# Altium schematic sheet Sheet2.SchDoc
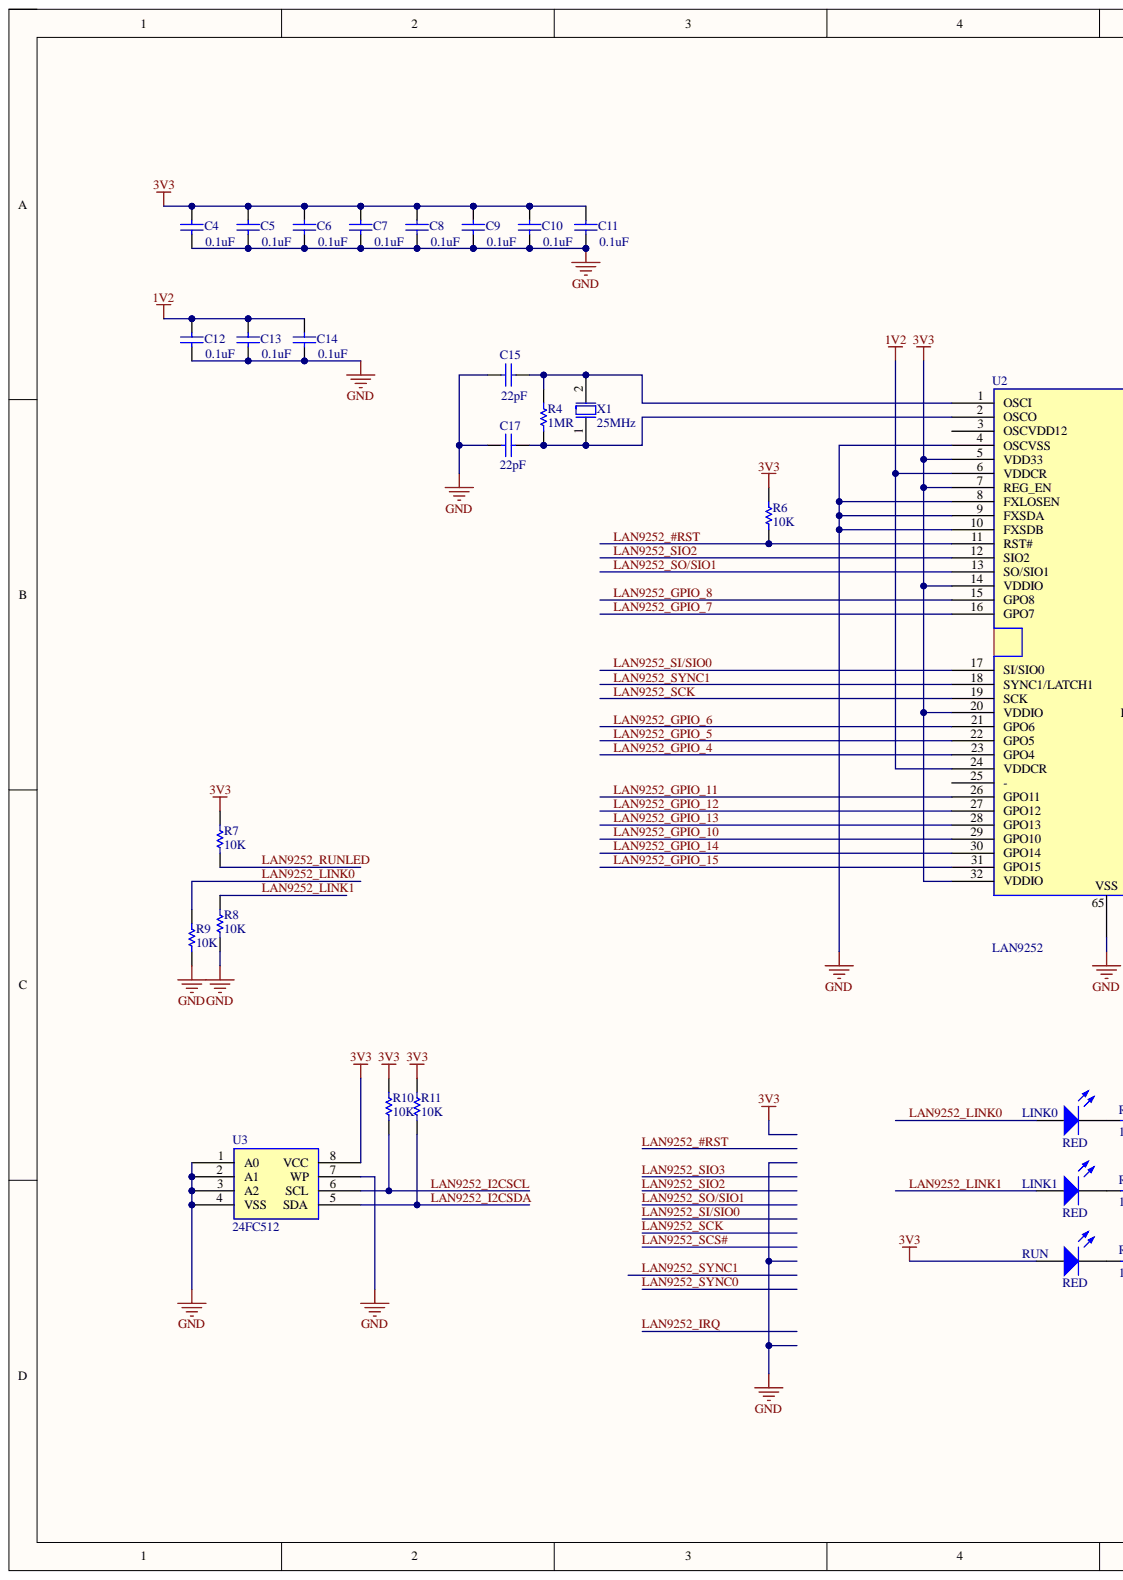
[diagram p0: Sheet2.SchDoc, left]
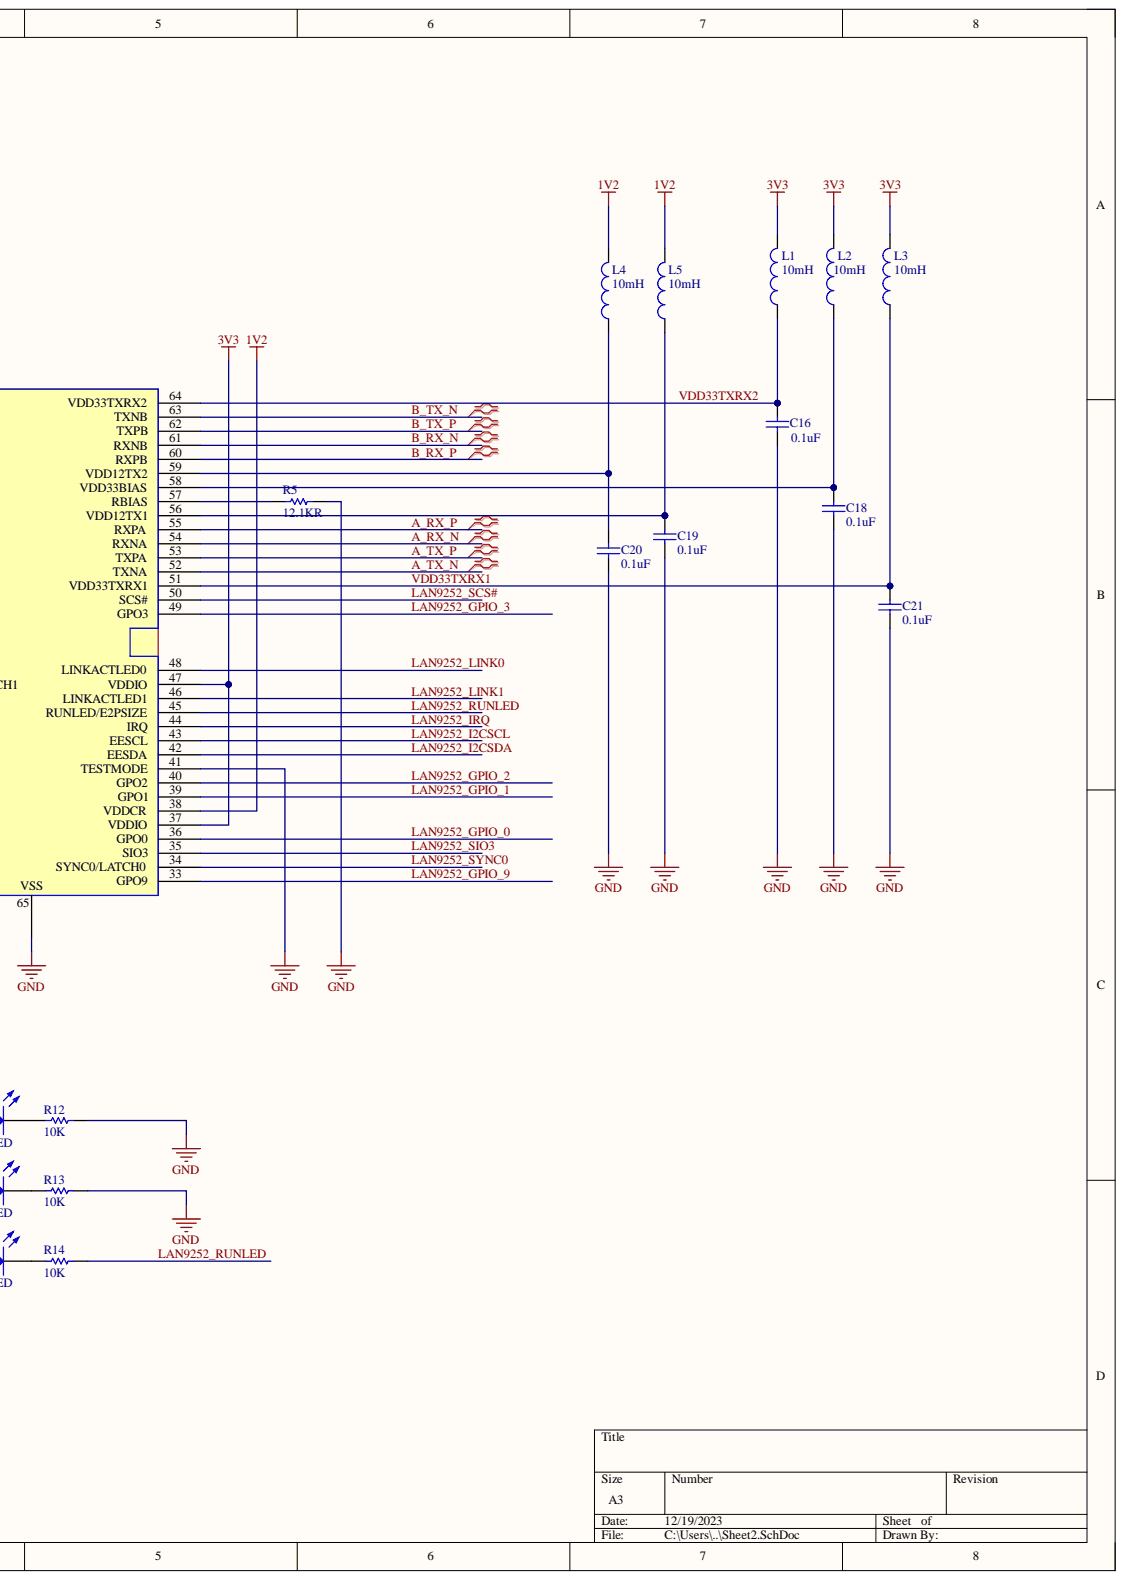
[diagram p1: Sheet2.SchDoc, right]

## Parts
C4  value 0.1uF  [Capacitor_1608]  (hidden comment: Condenser_1608)
C5  value 0.1uF  [Capacitor_1608]  (hidden comment: Condenser_1608)
C6  value 0.1uF  [Capacitor_1608]  (hidden comment: Condenser_1608)
C7  value 0.1uF  [Capacitor_1608]  (hidden comment: Condenser_1608)
C8  value 0.1uF  [Capacitor_1608]  (hidden comment: Condenser_1608)
C9  value 0.1uF  [Capacitor_1608]  (hidden comment: Condenser_1608)
C10  value 0.1uF  [Capacitor_1608]  (hidden comment: Condenser_1608)
C11  value 0.1uF  [Capacitor_1608]  (hidden comment: Condenser_1608)
C12  value 0.1uF  [Capacitor_1608]  (hidden comment: Condenser_1608)
C13  value 0.1uF  [Capacitor_1608]  (hidden comment: Condenser_1608)
C14  value 0.1uF  [Capacitor_1608]  (hidden comment: Condenser_1608)
C15  value 22pF  [Capacitor_1608]  (hidden comment: Condenser_1608)
C16  value 0.1uF  [Capacitor_1608]  (hidden comment: Condenser_1608)
C17  value 22pF  [Capacitor_1608]  (hidden comment: Condenser_1608)
C18  value 0.1uF  [Capacitor_1608]  (hidden comment: Condenser_1608)
C19  value 0.1uF  [Capacitor_1608]  (hidden comment: Condenser_1608)
C20  value 0.1uF  [Capacitor_1608]  (hidden comment: Condenser_1608)
C21  value 0.1uF  [Capacitor_1608]  (hidden comment: Condenser_1608)
L1  value 10mH  [INDUCTOR_1608]  (hidden comment: INDUCTOR_1608)
L2  value 10mH  [INDUCTOR_1608]  (hidden comment: INDUCTOR_1608)
L3  value 10mH  [INDUCTOR_1608]  (hidden comment: INDUCTOR_1608)
L4  value 10mH  [INDUCTOR_1608]  (hidden comment: INDUCTOR_1608)
L5  value 10mH  [INDUCTOR_1608]  (hidden comment: INDUCTOR_1608)
LINK0  value RED  [LED_1608]  (hidden comment: LED_1608)
LINK1  value RED  [LED_1608]  (hidden comment: LED_1608)
R4  value 1MR  [Resistance_1608]  (hidden comment: Resista_1608)
R5  value 12.1KR  [Resistance_1608]  (hidden comment: Resista_1608)
R6  value 10K  [Resistance_1608]  (hidden comment: Resista_1608)
R7  value 10K  [Resistance_1608]  (hidden comment: Resista_1608)
R8  value 10K  [Resistance_1608]  (hidden comment: Resista_1608)
R9  value 10K  [Resistance_1608]  (hidden comment: Resista_1608)
R10  value 10K  [Resistance_1608]  (hidden comment: Resista_1608)
R11  value 10K  [Resistance_1608]  (hidden comment: Resista_1608)
R12  value 10K  [Resistance_1608]  (hidden comment: Resista_1608)
R13  value 10K  [Resistance_1608]  (hidden comment: Resista_1608)
R14  value 10K  [Resistance_1608]  (hidden comment: Resista_1608)
RUN  value RED  [LED_1608]  (hidden comment: LED_1608)
U2  LAN9252  [LAN9252_QFP]
U3  24FC512  [24FC512T-I_SN]
X1  value 25MHz  [FA-238V]  (hidden comment: FA-238V)

## Nets
1V2: C12.2 C13.2 C14.2 L4.2 L5.2 U2.6(VDDCR) U2.24(VDDCR) U2.38(VDDCR)
3V3: C4.2 C5.2 C6.2 C7.2 C8.2 C9.2 C10.2 C11.2 L1.2 L2.2 L3.2 R6.1 R7.1 R10.1 R11.1 RUN.A U2.5(VDD33) U2.7(REG_EN) U2.14(VDDIO) U2.20(VDDIO) U2.32(VDDIO) U2.37(VDDIO) U2.47(VDDIO) U3.8(VCC)
GND: C4.1 C5.1 C6.1 C7.1 C8.1 C9.1 C10.1 C11.1 C12.1 C13.1 C14.1 C15.1 C16.1 C17.1 C18.1 C19.1 C20.1 C21.1 R5.2 R8.1 R9.1 R12.1 R13.1 U2.4(OSCVSS) U2.8(FXLOSEN) U2.9(FXSDA) U2.10(FXSDB) U2.41(TESTMODE) U2.65 U3.1(A0) U3.2(A1) U3.3(A2) U3.4(VSS) U3.7(WP)
A_RX_N: U2.54(RXNA)
A_RX_P: U2.55(RXPA)
A_TX_N: U2.52(TXNA)
A_TX_P: U2.53(TXPA)
B_RX_N: U2.61(RXNB)
B_RX_P: U2.60(RXPB)
B_TX_N: U2.63(TXNB)
B_TX_P: U2.62(TXPB)
LAN9252_#RST: R6.2 U2.11(RST#)
LAN9252_GPIO_0: U2.36(GPO0)
LAN9252_GPIO_1: U2.39(GPO1)
LAN9252_GPIO_2: U2.40(GPO2)
LAN9252_GPIO_3: U2.49(GPO3)
LAN9252_GPIO_4: U2.23(GPO4)
LAN9252_GPIO_5: U2.22(GPO5)
LAN9252_GPIO_6: U2.21(GPO6)
LAN9252_GPIO_7: U2.16(GPO7)
LAN9252_GPIO_8: U2.15(GPO8)
LAN9252_GPIO_9: U2.33(GPO9)
LAN9252_GPIO_10: U2.29(GPO10)
LAN9252_GPIO_11: U2.26(GPO11)
LAN9252_GPIO_12: U2.27(GPO12)
LAN9252_GPIO_13: U2.28(GPO13)
LAN9252_GPIO_14: U2.30(GPO14)
LAN9252_GPIO_15: U2.31(GPO15)
LAN9252_I2CSCL: R10.2 U2.43(EESCL) U3.6(SCL)
LAN9252_I2CSDA: R11.2 U2.42(EESDA) U3.5(SDA)
LAN9252_IRQ: U2.44(IRQ)
LAN9252_LINK0: LINK0.A R9.2 U2.48(LINKACTLED0)
LAN9252_LINK1: LINK1.A R8.2 U2.46(LINKACTLED1)
LAN9252_RUNLED: R7.2 R14.1 U2.45(RUNLED/E2PSIZE)
LAN9252_SCK: U2.19(SCK)
LAN9252_SCS#: U2.50(SCS#)
LAN9252_SI/SIO0: U2.17(SI/SIO0)
LAN9252_SIO2: U2.12(SIO2)
LAN9252_SIO3: U2.35(SIO3)
LAN9252_SO/SIO1: U2.13(SO/SIO1)
LAN9252_SYNC0: U2.34(SYNC0/LATCH0)
LAN9252_SYNC1: U2.18(SYNC1/LATCH1)
VDD33TXRX1: C21.2 L3.1 U2.51(VDD33TXRX1)
VDD33TXRX2: C16.2 L1.1 U2.64(VDD33TXRX2)
NetC15_2: C15.2 R4.2 U2.1(OSCI) X1.2
NetC17_2: C17.2 R4.1 U2.2(OSCO) X1.1
NetC18_2: C18.2 L2.1 U2.58(VDD33BIAS)
NetC19_2: C19.2 L5.1 U2.56(VDD12TX1)
NetC20_2: C20.2 L4.1 U2.59(VDD12TX2)
NetLINK0_C: LINK0.C R12.2
NetLINK1_C: LINK1.C R13.2
NetR5_1: R5.1 U2.57(RBIAS)
NetR14_2: R14.2 RUN.C

Not connected: U2 3(OSCVDD12) 25(-)

## Library data of the parts
C4-C21 = Condenser_1608: Component Kind: Standard; Library Name: MyLaibrary.SchLib
L1-L5 = INDUCTOR_1608: Component Kind: Standard; Library Name: MyLaibrary.SchLib
LINK0 LINK1 RUN = LED_1608: Component Kind: Standard; Library Name: MyLaibrary.SchLib
R4-R14 = Resista_1608: Component Kind: Standard; Library Name: MyLaibrary.SchLib
U2 = LAN9252: Component Kind: Standard; Value: LAN9252; Library Name: MyLaibrary.SchLib
U3 = 24FC512: Component Kind: Standard; Value: 24FC512; Library Name: MyLaibrary.SchLib
X1 = FA-238V: Component Kind: Standard; Library Name: MyLaibrary.SchLib
